# Altium schematic sheet middle_tower.SchDoc
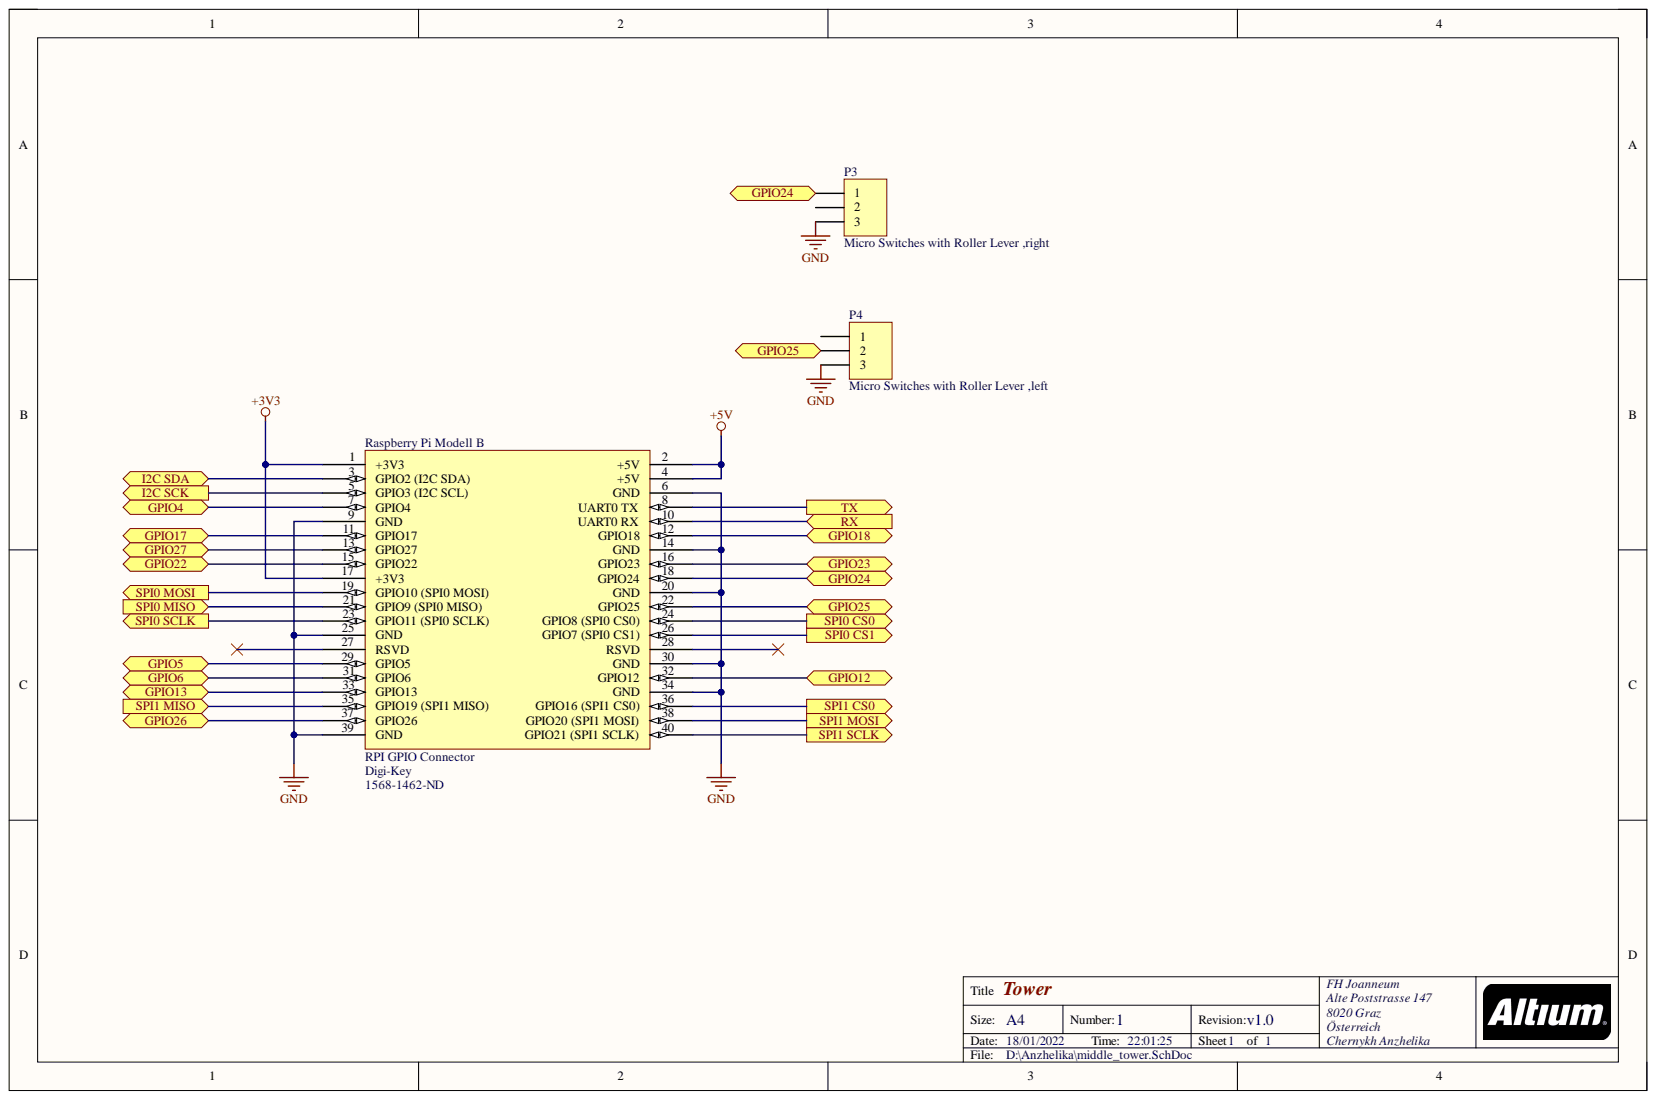
[diagram p0: middle_tower.SchDoc]

## Parts
P3  Micro Switches with Roller Lever ,right  [HDR1X3H]
P4  Micro Switches with Roller Lever ,left  [HDR1X3H]
Raspberry_Pi_Modell_B  RPI GPIO Connector  Accessory Type: Connector  For Use With/Related Products: Raspberry Pi  Supplier 1: Digi-Key  [rpi]

## Ports
GPIO4, GPIO5, GPIO6, GPIO12, GPIO13, GPIO17, GPIO18, GPIO22, GPIO23, GPIO24, GPIO25, GPIO26, GPIO27, I2C SCK, I2C SDA, RX, SPI0 CS0, SPI0 CS1, SPI0 MISO, SPI0 MOSI, SPI0 SCLK, SPI1 CS0, SPI1 MISO, SPI1 MOSI, SPI1 SCLK, TX

## Nets
+3V3: Raspberry_Pi_Modell_B.1(+3V3) Raspberry_Pi_Modell_B.17(+3V3)
+5V: Raspberry_Pi_Modell_B.2(+5V) Raspberry_Pi_Modell_B.4(+5V)
GND: P3.3 P4.3 Raspberry_Pi_Modell_B.6(GND) Raspberry_Pi_Modell_B.9(GND) Raspberry_Pi_Modell_B.14(GND) Raspberry_Pi_Modell_B.20(GND) Raspberry_Pi_Modell_B.25(GND) Raspberry_Pi_Modell_B.30(GND) Raspberry_Pi_Modell_B.34(GND) Raspberry_Pi_Modell_B.39(GND)
GPIO4: Raspberry_Pi_Modell_B.7(GPIO4) ; port GPIO4
GPIO5: Raspberry_Pi_Modell_B.29(GPIO5) ; port GPIO5
GPIO6: Raspberry_Pi_Modell_B.31(GPIO6) ; port GPIO6
GPIO12: Raspberry_Pi_Modell_B.32(GPIO12) ; port GPIO12
GPIO13: Raspberry_Pi_Modell_B.33(GPIO13) ; port GPIO13
GPIO17: Raspberry_Pi_Modell_B.11(GPIO17) ; port GPIO17
GPIO18: Raspberry_Pi_Modell_B.12(GPIO18) ; port GPIO18
GPIO22: Raspberry_Pi_Modell_B.15(GPIO22) ; port GPIO22
GPIO23: Raspberry_Pi_Modell_B.16(GPIO23) ; port GPIO23
GPIO24: P3.1 Raspberry_Pi_Modell_B.18(GPIO24) ; port GPIO24
GPIO25: P4.2 Raspberry_Pi_Modell_B.22(GPIO25) ; port GPIO25
GPIO26: Raspberry_Pi_Modell_B.37(GPIO26) ; port GPIO26
GPIO27: Raspberry_Pi_Modell_B.13(GPIO27) ; port GPIO27
I2C SCK: Raspberry_Pi_Modell_B.5(GPIO3_(I2C_SCL)) ; port I2C SCK
I2C SDA: Raspberry_Pi_Modell_B.3(GPIO2_(I2C_SDA)) ; port I2C SDA
RX: Raspberry_Pi_Modell_B.10(UART0_RX) ; port RX
SPI0 CS0: Raspberry_Pi_Modell_B.24(GPIO8_(SPI0_CS0)) ; port SPI0 CS0
SPI0 CS1: Raspberry_Pi_Modell_B.26(GPIO7_(SPI0_CS1)) ; port SPI0 CS1
SPI0 MISO: Raspberry_Pi_Modell_B.21(GPIO9_(SPI0_MISO)) ; port SPI0 MISO
SPI0 MOSI: Raspberry_Pi_Modell_B.19(GPIO10_(SPI0_MOSI)) ; port SPI0 MOSI
SPI0 SCLK: Raspberry_Pi_Modell_B.23(GPIO11_(SPI0_SCLK)) ; port SPI0 SCLK
SPI1 CS0: Raspberry_Pi_Modell_B.36(GPIO16_(SPI1_CS0)) ; port SPI1 CS0
SPI1 MISO: Raspberry_Pi_Modell_B.35(GPIO19_(SPI1_MISO)) ; port SPI1 MISO
SPI1 MOSI: Raspberry_Pi_Modell_B.38(GPIO20_(SPI1_MOSI)) ; port SPI1 MOSI
SPI1 SCLK: Raspberry_Pi_Modell_B.40(GPIO21_(SPI1_SCLK)) ; port SPI1 SCLK
TX: Raspberry_Pi_Modell_B.8(UART0_TX) ; port TX

Not connected: P3 2; P4 1; Raspberry_Pi_Modell_B 27(RSVD) 28(RSVD)

## Library data of the parts
P3 P4 = Header 3H: Header, 3-Pin, Right Angle; Component Kind: Standard; LatestRevisionDate: 17-Jul-2002; LatestRevisionNote: Re-released for DXP Platform.; Library Name: Miscellaneous Connectors.IntLib; Publisher: Altium Limited
Raspberry_Pi_Modell_B = rpi connector: GPIO Header for Raspberry Pi A+/B+/Pi 2/Pi 3 - 2x20; Manufacturer: SparkFun Electronics; Manufacturer Part Number: PRT-14017; Nils Minor: author; nilsminor@web.de: mail; Category: Development Boards, Kits, Programmers; Component Kind: Standard; ComponentLink1Description: https://cdn.sparkfun.com/datasheets/Dev/RaspberryPi/12313.pdf; ComponentLink1URL: https://cdn.sparkfun.com/datasheets/Dev/RaspberryPi/12313.pdf; Library Name: rpi.SchLib; Part Status: Active; Pricing 1: 1=1.84 (EUR); RoHS: RoHS Compliant; Stock 1: 150; Supplier Part Number 1: 1568-1462-ND
